# Altium schematic sheet POWER-INPUT.SchDoc(POWER-INPUT)
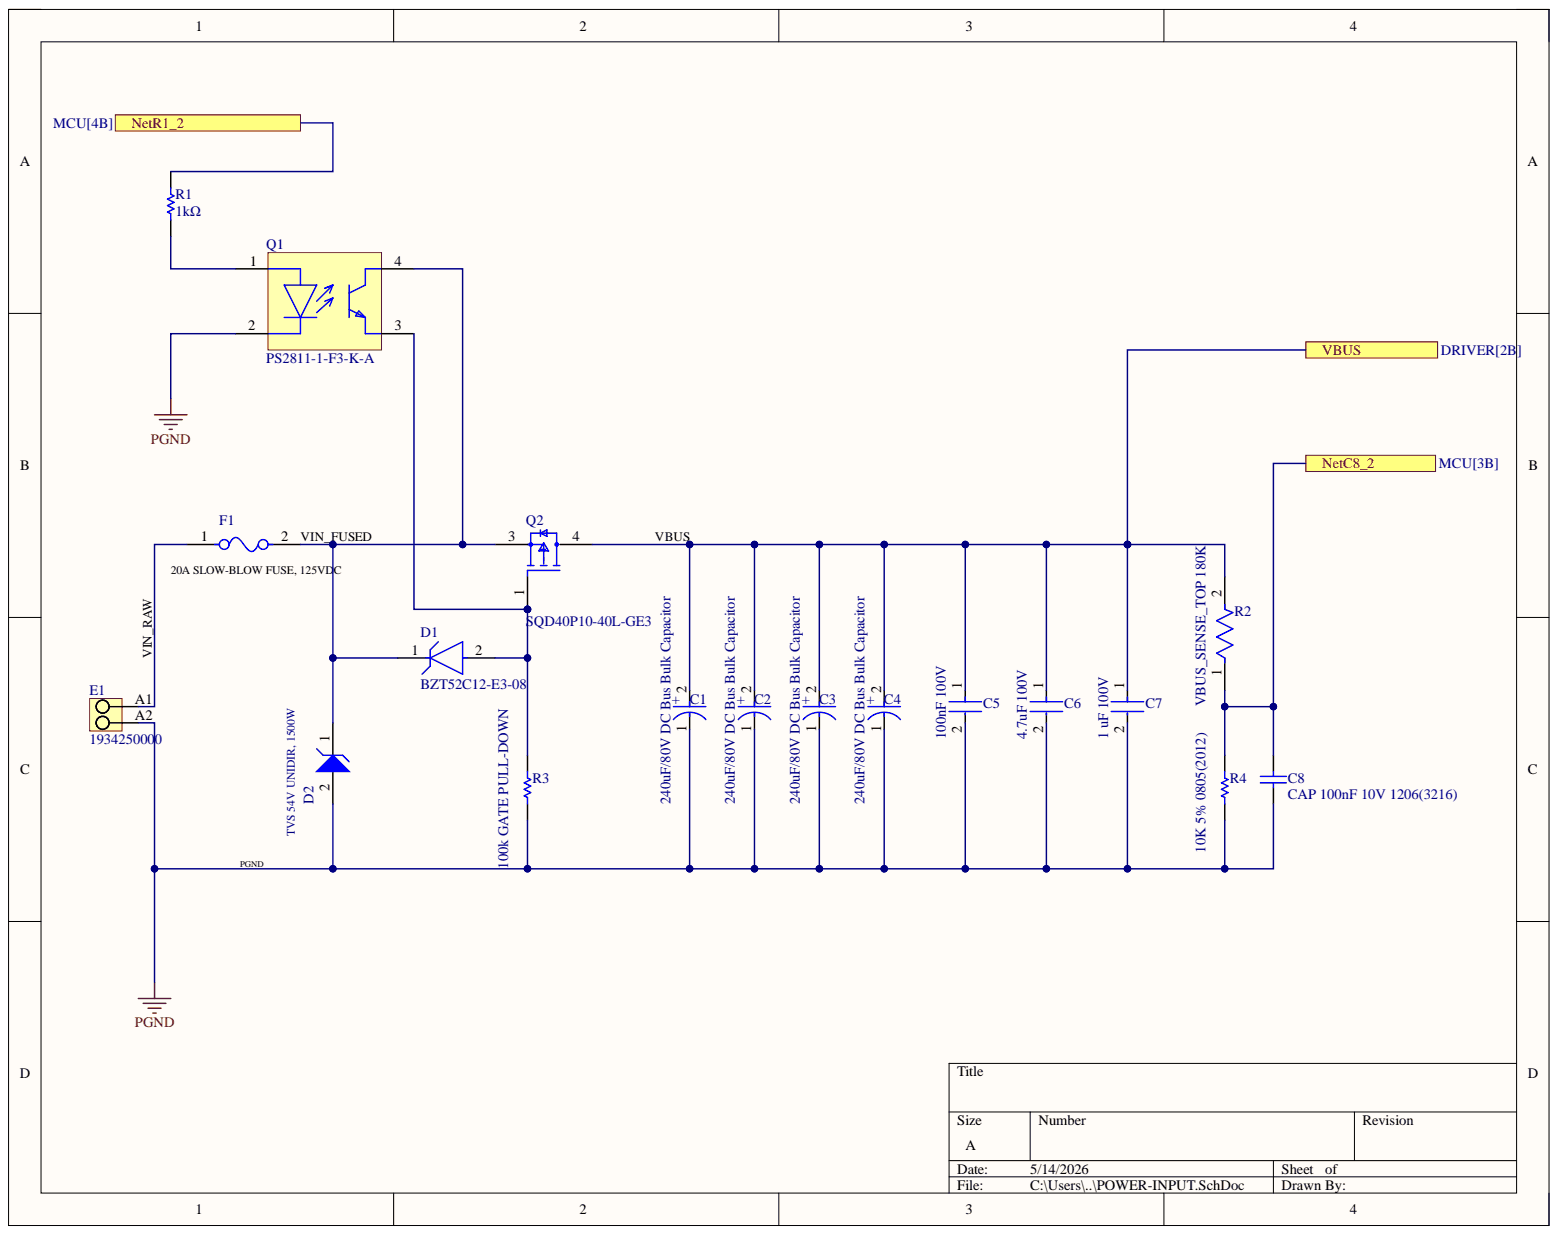
[diagram p0: POWER-INPUT.SchDoc]

## Parts
C1  240uF/80V DC Bus Bulk Capacitor  [FP-EMHS800ARA241MKG5S-MFG]
C2  240uF/80V DC Bus Bulk Capacitor  [FP-EMHS800ARA241MKG5S-MFG]
C3  240uF/80V DC Bus Bulk Capacitor  [FP-EMHS800ARA241MKG5S-MFG]
C4  240uF/80V DC Bus Bulk Capacitor  [FP-EMHS800ARA241MKG5S-MFG]
C5  100nF 100V  Capacitance: 100nF  Voltage Rating: 100V  [FP-VJ1206Y104KXBAT-IPC_A]
C6  4.7uF 100V  [FP-GRM32D-0_3-IPC_C]
C7  1 uF 100V  Voltage Rating: 100V  [FP-C3225-200-0_4-IPC_A]
C8  CAP 100nF 10V 1206(3216)  value 100nF  [CAPC1206(3216)190_N]
D1  BZT52C12-E3-08  [FP-S8-V-3910_01-003-MFG]
D2  TVS 54V UNIDIR, 1500W  Reverse Standoff Voltage: 54V  [WE-TVSP_DO-214AB_Unidirectional]
E1  1934250000  [LL_5_08_90_02]
F1  20A SLOW-BLOW FUSE, 125VDC  [FP-0683G-IPC_C]
Q1  PS2811-1-F3-K-A  [SO4-Opto2_MFG]
Q2  SQD40P10-40L-GE3  [FP-T13-0592-MFG]
R1  1kΩ  [RESC2013X50X30ML20T20]
R2  VBUS_SENSE_TOP 180K  [FP-CRCW2512-e3-MFG]
R3  100k GATE PULL-DOWN  [RESC0603(1608)_N]
R4  10K 5% 0805(2012)  [RESC0805(2012)_L]

## Ports
BUS_VSENSE, Q2_GATE_OFF_CTRL, VBUS_MOTOR

## Nets
PGND: C1.1 C2.1 C3.1 C4.1 C5.2 C6.2 C7.2 C8.1 D2.2 E1.A2 Q1.2 R3.1 R4.1
VBUS: C1.2 C2.2 C3.2 C4.2 C5.1 C6.1 C7.1 Q2.4 R2.2 ; port VBUS_MOTOR
VIN_FUSED: D1.1 D2.1 F1.2 Q1.4 Q2.3
VIN_RAW: E1.A1 F1.1
NetC8_2: C8.2 R2.1 R4.2 ; port BUS_VSENSE
NetD1_2: D1.2 Q1.3 Q2.1 R3.2
NetQ1_1: Q1.1 R1.1
NetR1_2: R1.2 ; port Q2_GATE_OFF_CTRL

## Library data of the parts
C1-C4 = CMP-00611-89901583-1: EMHS800ARA241MKG5S Cap Aluminum Lytic 240uF 80V 20% (12.5 X 16mm) SMD 0.13 Ohm 1030mA 5000h 125°C Automotive T/R; Capacitance: 240µF; Dielectric Material: Aluminium; Dissipation Factor: 12%; ESR (Equivalent Series Resistance): 130mΩ; Lead/Base Style: Straight; Life (Hours): 5000hours; Polarity: Polar; Ripple Current: 1.03A; Test Frequency: 100MHz; Case/Package: SMD/SMT; Component Kind: Standard; Contact Plating: Tin; Diameter: 12.5mm; Height: 16mm; Lead Free: Lead Free; Length: 16mm; Library Name: Altium Content Vault; Mount: Surface Mount; Number of Pins: 2; Packaging: Tape & Reel (TR); Radiation Hardening: No; REACH SVHC: No SVHC; RoHS: Compliant; Schedule B: 853222***; Termination: SMD/SMT; Tolerance: 20%; Voltage: 80V
C5 = CMP-00030-39693282-1: VJ1206Y104KXBAT Multilayer Ceramic Capacitor, 0.1 uF, 100 V, ± 10%, X7R, 1206 [3216 Metric]; Case Code (Imperial): 1206; Case Code (Metric): 3216; Case/Package: 1206; Component Kind: Standard; Depth: 1.6mm; Dielectric: X7R; Lead Free: Lead Free; Length: 3.2mm; Library Name: Altium Content Vault; Max Operating Temperature: 125°C; Min Operating Temperature: -55°C; Mount: Surface Mount; NexarPartId: 39693282; Number of Pins: 2; Packaging: Cut Tape; Radiation Hardening: No; REACH SVHC: Unknown; RoHS: Compliant; Schedule B: 8532240020, 8532240020; Termination: SMD/SMT; Tolerance: 10%; Voltage Rating (DC): 100V; Weight: 16.187578mg; Width: 1.6mm
C6 = CMP-06035-063252-1: Chip Multilayer Ceramic Capacitors for General Purpose 4.7μF ±10% 100VDC  SMD 1210; Component Kind: Standard; Library Name: Altium Content Vault
C7 = CMP-08246-006237-1: Multilayer Ceramic Capacitors 1uF ±20% 100V X7R SMD 1210; Capacitance: 1uF; Case Code (Imperial): 1210; Case Code (Metric): 3225; Case/Package: 1210; Component Kind: Standard; Depth: 2.5mm; Dielectric: X7R; Height: 2mm; Lead Free: Lead Free; Length: 3.2mm; Library Name: Altium Content Vault; Max Operating Temperature: 125°C; Min Operating Temperature: -55°C; Mount: Surface Mount; Number of Pins: 2; Package Quantity: 1; Packaging: Tape and Reel; REACH SVHC: No SVHC; Resistor Type: Ceramic; RoHS Compliant: Yes; Series: C; Termination: SMD/SMT; Thickness: 0.087inch; Tolerance: 20%; Voltage Rating (DC): 100V; Weight: 0.000564oz; Width: 2.5mm
C8 = CMP-1037-04171-1: CAP 100nF 10V ±5% 1206 (3216 Metric) Thickness 1.9mm SMD; Case-EIA: 1206; Case-Metric: 3216; Tolerance: Â±5%; Component Kind: Standard; Library Name: Altium Content Vault; Max Thickness: 1.9 mm; Rated Voltage: 10 V; Technology: SMT
D1 = CMP-00030-30578875-1: BZT52C12-E3-08 BZT52 Series 12 V 100 nA 410 mW SMT Small Signal Zener Diode - SOD-123; Element Configuration: Single; ESD Protection: Yes; Impedance: 7Ω; Max Power Dissipation: 410mW; Max Reverse Leakage Current: 100nA; Test Current: 5mA; Tolerance: 5%; Working Voltage: 12V; Zener Current: 28mA; Component Kind: Standard; Contact Plating: Tin; Lead Free: Lead Free; Library Name: Altium Content Vault; Max Operating Temperature: 150°C; Min Operating Temperature: -55°C; Mount: Surface Mount; NexarPartId: 30578875; Number of Pins: 2; Packaging: Cut Tape; Peak Reverse Current: 100nA; Power Dissipation: 410mW; Radiation Hardening: No; RoHS: Compliant; Schedule B: 8541100050, 8541100050; Voltage Tolerance: 5%; Weight: 10.290877mg; Zener Voltage: 12V
D2 = CMP-03913-71101669-2: TVS diode [Würth Elektronik] 824540541 TVS diode; Breakdown Voltage: 63.15V; Clamping Voltage: 87.1V; Leakage Current: 1µA; Number of Unidirectional Channels: 1; Peak Pulse Current: 17.3A; Peak Pulse Power: 1.5kW; Polarity: Unidirectional; Power Line Protection: No; Component Kind: Standard; Composition: Zener; Height: 2.5mm; Length: 6.86mm; Library Name: Altium Content Vault; Max Breakdown Voltage: 63.15V; Max Operating Temperature: 150°C; Min Breakdown Voltage: 63.15V; Min Operating Temperature: -65°C; Mount: Surface Mount; Number of Pins: 2; Packaging: Cut Tape; RoHS: Compliant; Width: 5.91mm
E1 = CMP-1559-01669-1: OMNIMATE Signal - series LL; Manufacturer: Weidmueller; Contact_material: Cu-Leg; Contact_surface: tinned; Insulating_material: Wemid (PA); Mounting_onto_the_PCB: Solder connection; No_of_poles: 2; Pitch_inch: 0,2 inch; Pitch_mm: 5,08 mm; Rated_current_use_group_B_UL_1059: 20 A; Rated_voltage_for_surge_voltage_class_pollution_degree_II_2: 500 V; Rated_voltage_use_group_B_UL_1059: 300 V; Short_text_for_material: LL 5.08/02/90 3.2SN OR BX; Side_termination_characteristic: closed side; Solder_pin_length_mm: 3,2 mm; Solid_max_H05_07_V_U: 6 mm²; Solid_min_H05_07_V_U: 0,5 mm²; UL_94_flammability_rating: V-0; Wire_cross_section_AWG_max_UL_1059: AWG 12; Wire_cross_section_AWG_min_UL_1059: AWG 28; Colour: Orange; Component Kind: Standard; ComponentLink1Description: Manufacturer URL; ComponentLink1URL: http://www.weidmueller.com/; ComponentLink2Description: URL Link1; ComponentLink2URL: http://catalog.weidmueller.com/catalog/Start.do?localeId=en&ObjectID=1934250000; Library Name: Altium Content Vault; PackageReference: LL_5_08_90_02; Packaging: Box; PartNumber: 1934250000; Qty: 100 PCS; Revision: V1
F1 = CMP-16042-000014-1: FUSE SLOW 125VDC 350VAC 20A; Component Kind: Standard; Library Name: Altium Content Vault
Q1 = CMP-00572-30507034-4: Optocoupler, Transistor, 2.5Kv, Ssop-4 Rohs Compliant: Yes; Manufacturer Lifecycle Status: ACTIVE; Case/Package: SSOP; China RoHS: Compliant; Component Kind: Standard; ComponentLink1Description: Manufacturer's Website; ComponentLink1URL: http://www.renesas.com; ComponentLink2Description: Datasheet URL; ComponentLink2URL: https://octopart.com/cad-content/api/get-model-datasheet/15189/30507034; Export Control Classification Number (ECCN) Code: EAR99; Height: 2.1mm; HelpURL: https://octopart.com/cad-content/api/get-model-datasheet/15189/30507034; Library Name: Altium Content Vault; Lifecycle Status: Production; Number of Pins: 4; RoHS: Compliant
Q2 = CMP-02550-000115-2: MOSFET P-CHAN 100V TO252; Manufacturer: Vishay; Automotive Grade: Grade 0; Continuous Drain Current: -38A; Depletion Mode: False; Drain To Source Breakdown Voltage: -100V; Drain To Source Resistance: 0.033Ω; Gate Charge At Vgs: 96nC @ -10V; Gate To Source Voltage: 20V; Input Capacitace At Vds: 4433pF @ -25V; Max Forward Diode Voltage: -1.5V; Max Junction Temp: +175°C; Number Of Channels: 1; Power Dissipation: 136W; Pulse Drain Current: -150A; Rthja Max: 50°C/W; Threshold Vgs Max: -2.5V; Threshold Vgs Min: -1V; Transconductance: 35S; Turn Off Delay Time: 78ns; Turn On Delay Time: 11ns; Typ Forward Diode Voltage: -0.8V; Automotive: Yes; Category: Trans; Component Kind: Standard; ComponentLink2Description: Datasheet; ComponentLink2URL: http://www.vishay.com/docs/67022/sqd40p10-40l.pdf; Device Class L1: Discrete Semiconductors; Device Class L2: Transistors; Device Class L3: MOSFETs; Digikey Description: MOSFET P-CHAN 100V TO252; Drain To Source Voltage: -100V; Height: 2.507mm; Lead Free: Yes; Library Name: Altium Content Vault; Manufacturer Part Number: SQD40P10-40L-GE3; Mouser Description: MOSFET -100V -30A 136W AEC-Q101 Qualified; Number Of P Channels: 1; Octopart: https://octopart.com/sqd40p10-40l_ge3-vishay-65895018; Package: T13-0592; RoHS: TRUE; Temperature: -55°C to +175°C; Temperature Range High: +175°C; Temperature Range Low: -55°C
R1 = CMP-2001-04268-1: Manufacturer: Vishay Dale; Part Number: CRCW08051K00FKTC; Component Kind: Standard; Library Name: Altium Content Vault
R2 = CMP-1018-00128-2: RES Thick Film, 180kΩ, 5%, 1W, 200ppm/°C, 2512; Resistance: 180kR; Voltage Rating: 500V; Case Code (Imperial): 2512; Case Code (Metric): 6432; Case/Package: 2512; Component Kind: Standard; Composition: Thick Film; Contact Plating: Tin; Depth: 3.15mm; Features: Automotive AEC-Q200; Height: 0.028inch; Lead Free: Lead Free; Length: 6.3mm; Library Name: Altium Content Vault; Max Operating Temperature: 155°C; Max Power Dissipation: 1W; Military Standard: Not; Min Operating Temperature: -55°C; Number of Terminations: 2; Package Quantity: 1; Packaging: Tape and Reel; Power Rating: 1W; Radiation Hardening: No; Temperature Coefficient: 200ppm/°C; Termination: SMD/SMT; Tolerance: 5%; Voltage Rating (DC): 500V; Weight: 0.001429oz; Width: 0.124inch
R3 = CMP-1012-00122-1: 100K 0.1W 5% 0603 (1608 Metric)  SMD; Case-EIA: 0603; Case-Metric: 1608; Value: 100000.00 Ohm; Component Kind: Standard; Library Name: Altium Content Vault; Power: 0.1 W; Technology: SMT; Tolerance: 5 %
R4 = CMP-1013-00098-1: 10K 0.125W 5% 0805 (2012 Metric)  SMD; Case-EIA: 0805; Case-Metric: 2012; Value: 10000.00 Ohm; Component Kind: Standard; Library Name: Altium Content Vault; Power: 0.125 W; Technology: SMT; Tolerance: 5 %
